# Altium schematic sheet Analog Transmission.SchDoc
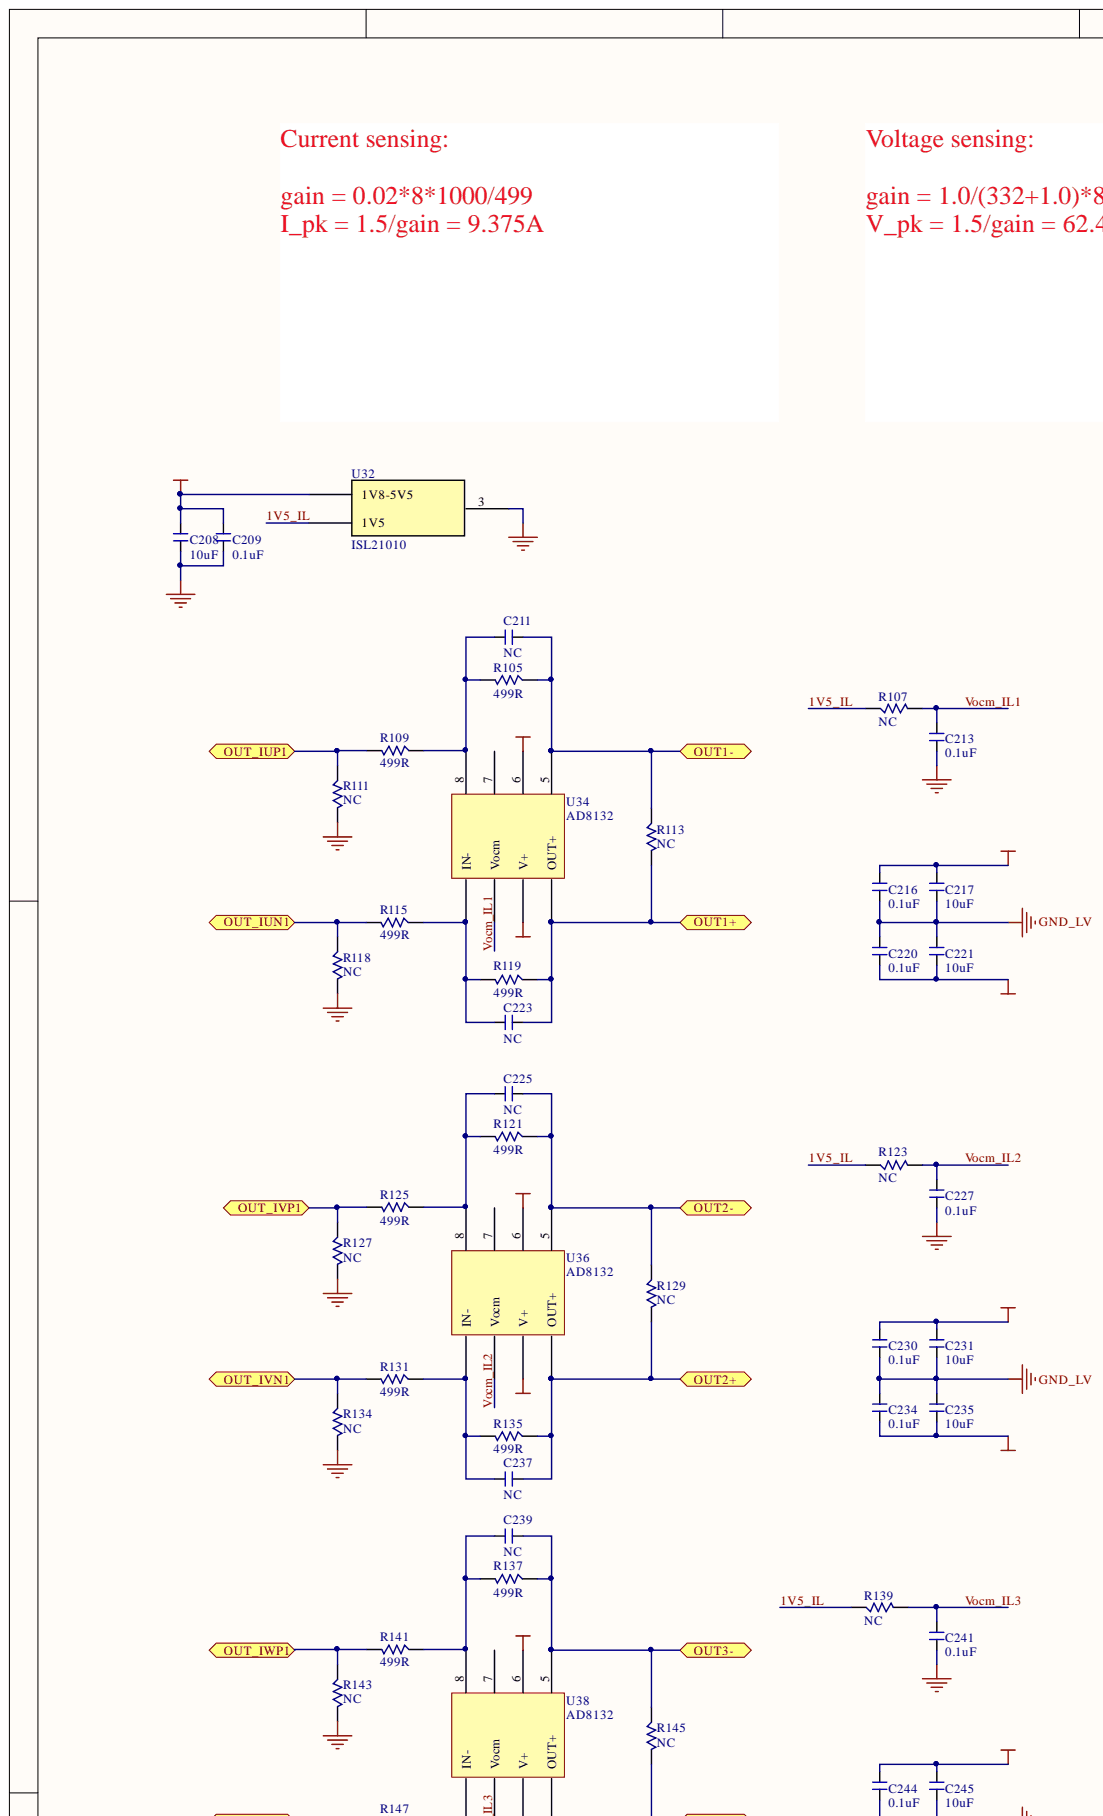
[diagram p0: Analog Transmission.SchDoc, top left]
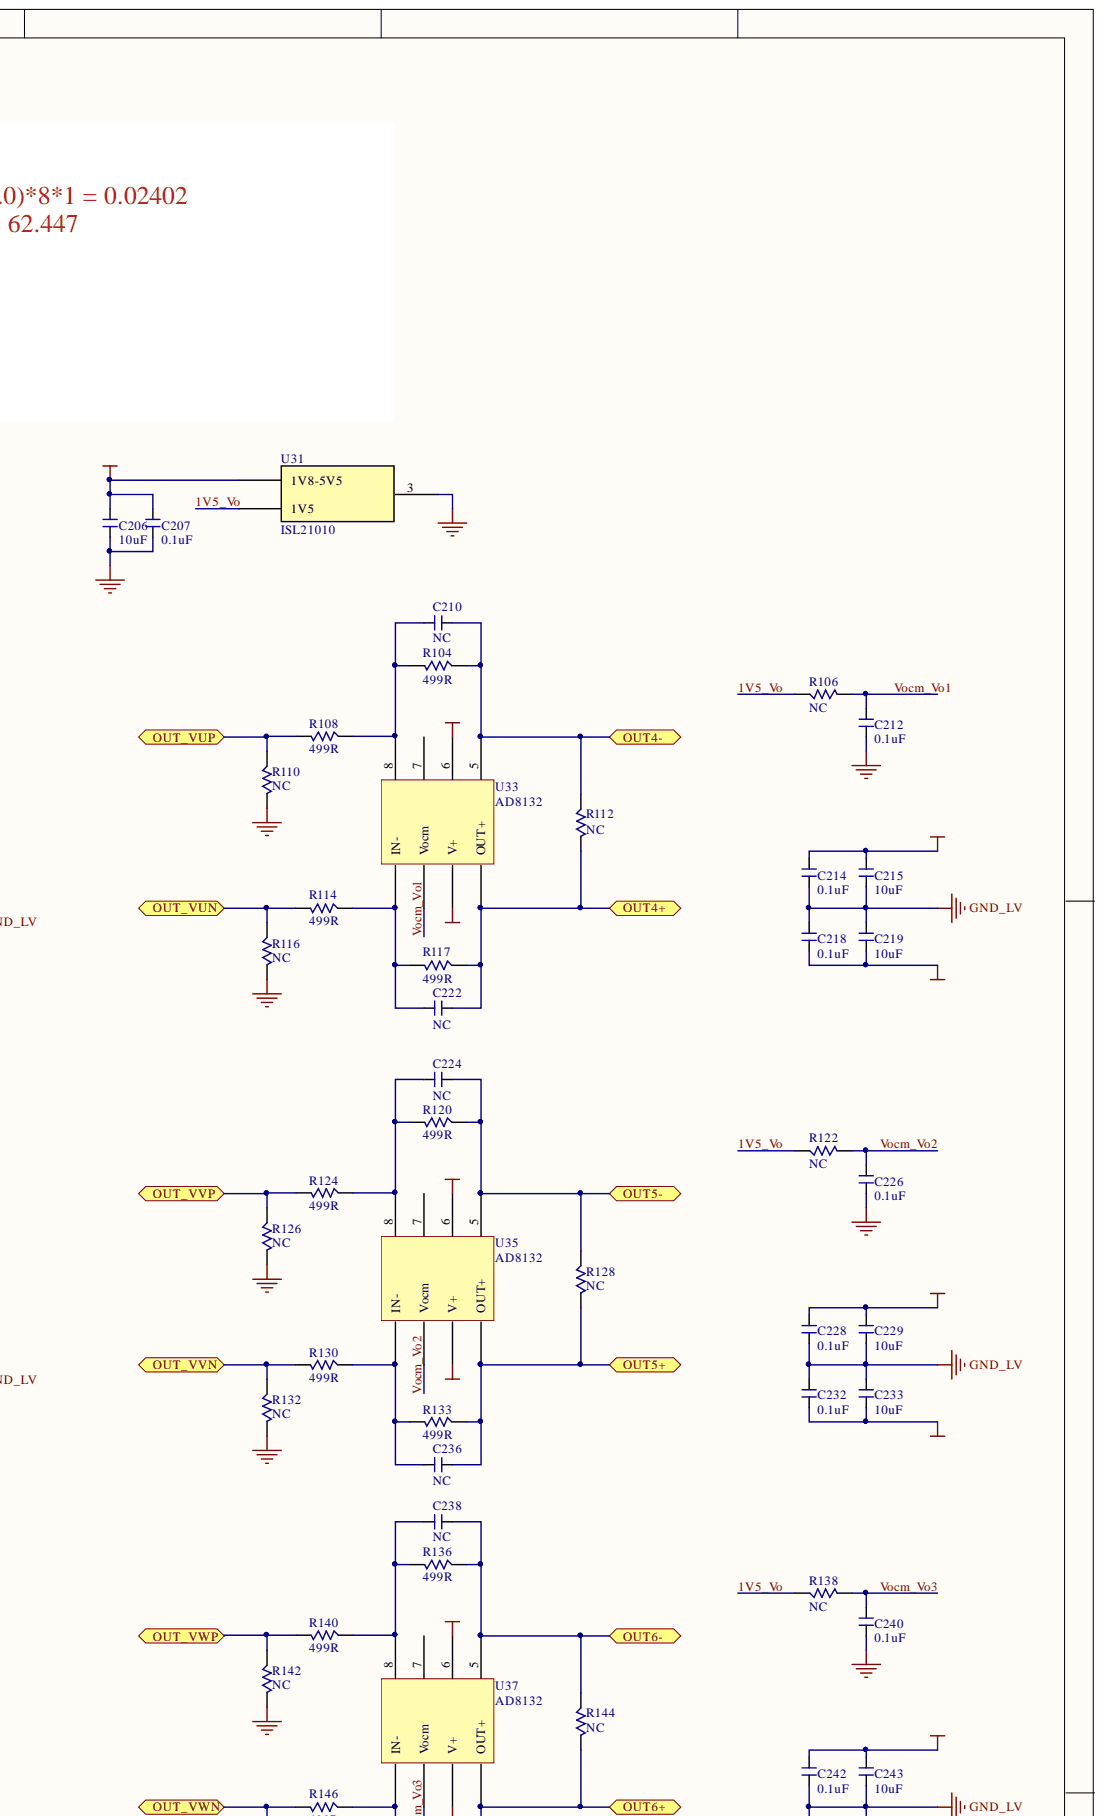
[diagram p1: Analog Transmission.SchDoc, top right]
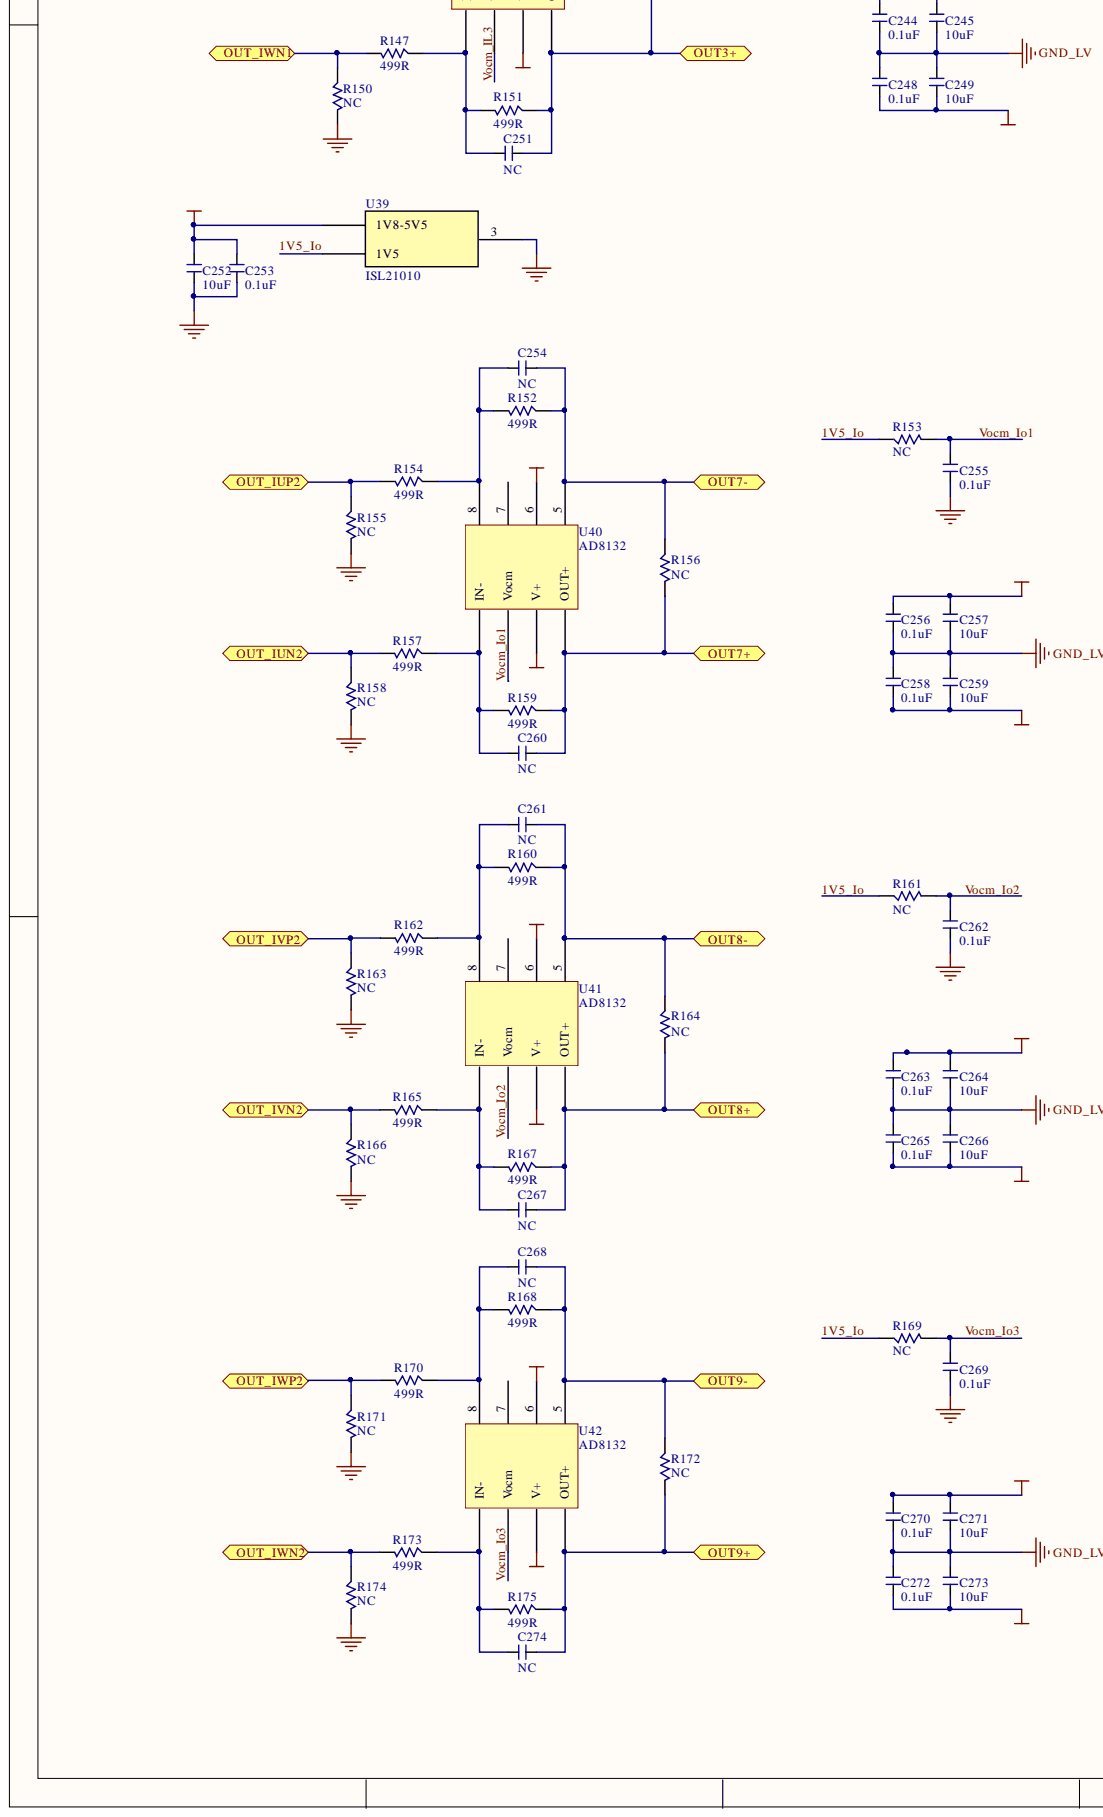
[diagram p2: Analog Transmission.SchDoc, bottom left]
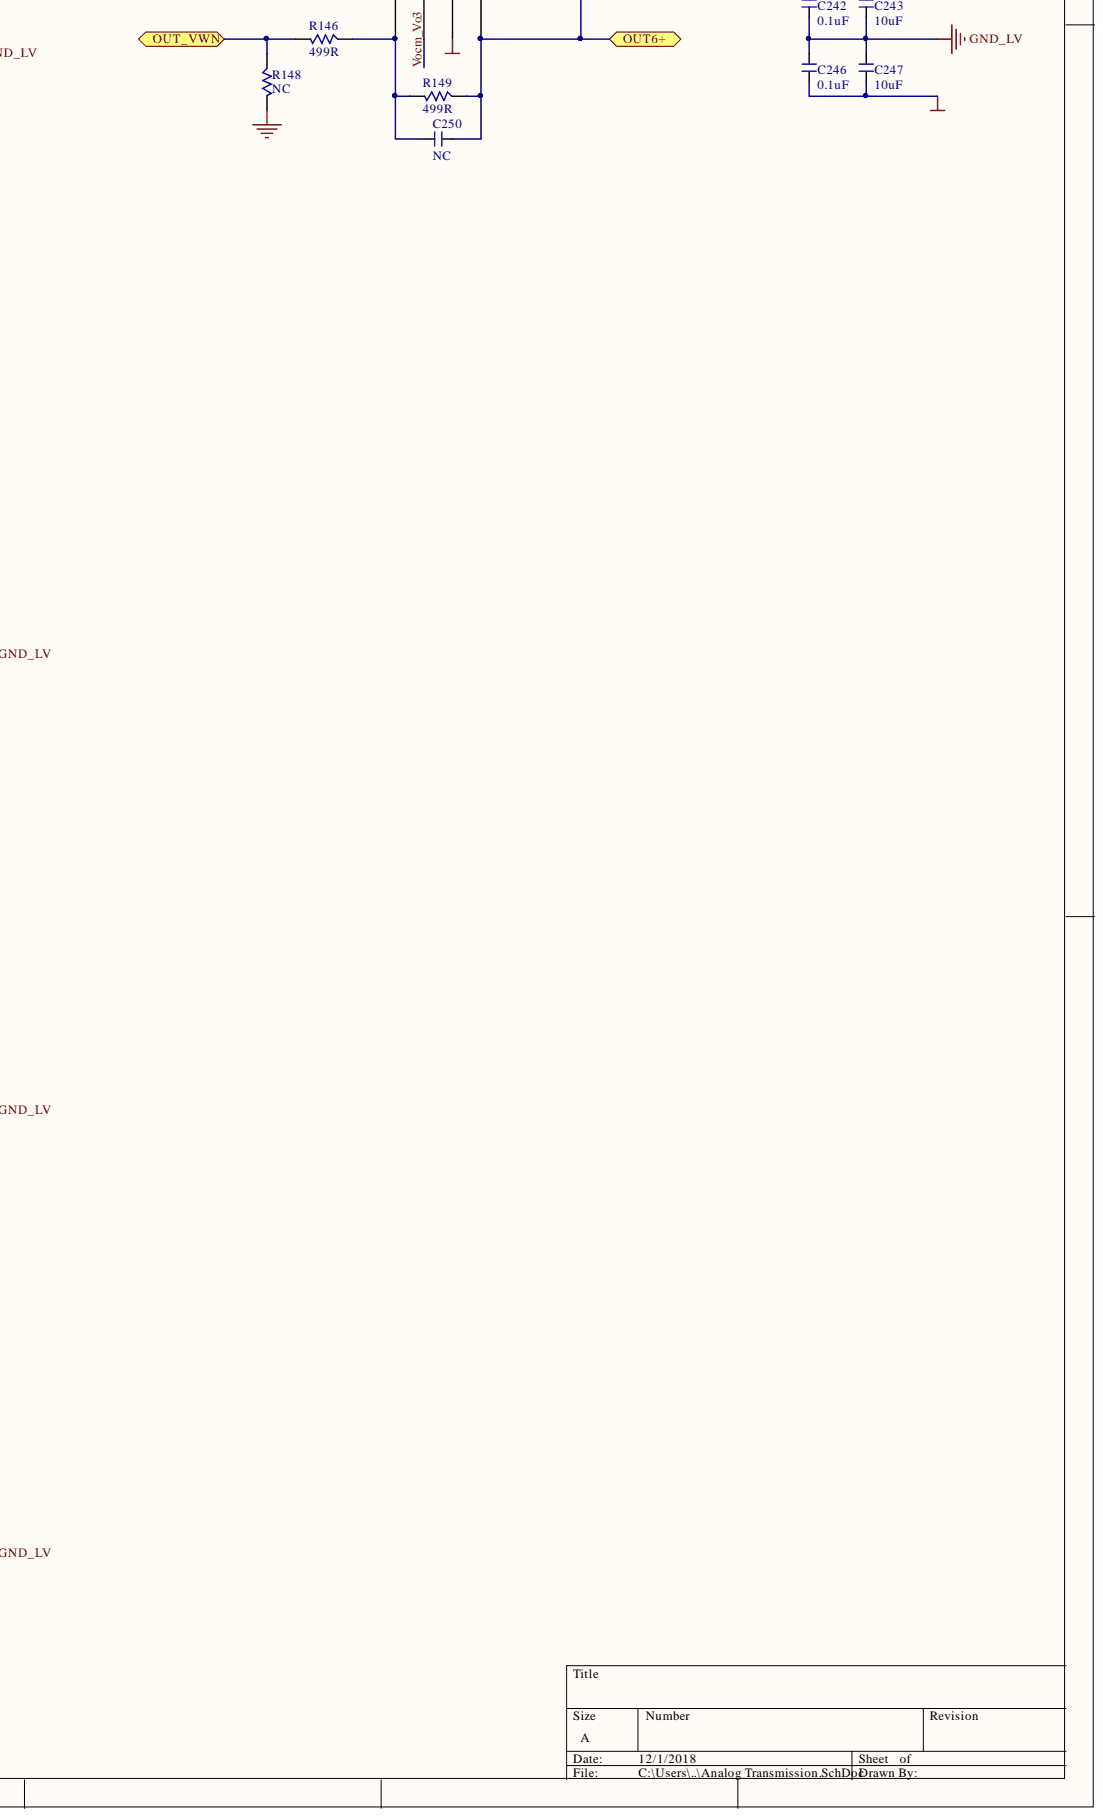
[diagram p3: Analog Transmission.SchDoc, bottom right]

## Parts
C206  value 10uF  [0603 (1608 Metric)]  (hidden comment: Cap 0603_new)
C207  value 0.1uF  [0603 (1608 Metric)]  (hidden comment: Cap 0603_new)
C208  value 10uF  [0603 (1608 Metric)]  (hidden comment: Cap 0603_new)
C209  value 0.1uF  [0603 (1608 Metric)]  (hidden comment: Cap 0603_new)
C210  value NC  [0603 (1608 Metric)]  (hidden comment: Cap 0603_new)
C211  value NC  [0603 (1608 Metric)]  (hidden comment: Cap 0603_new)
C212  value 0.1uF  [0603 (1608 Metric)]  (hidden comment: Cap 0603_new)
C213  value 0.1uF  [0603 (1608 Metric)]  (hidden comment: Cap 0603_new)
C214  value 0.1uF  [0603 (1608 Metric)]  (hidden comment: Cap 0603_new)
C215  value 10uF  [0603 (1608 Metric)]  (hidden comment: Cap 0603_new)
C216  value 0.1uF  [0603 (1608 Metric)]  (hidden comment: Cap 0603_new)
C217  value 10uF  [0603 (1608 Metric)]  (hidden comment: Cap 0603_new)
C218  value 0.1uF  [0603 (1608 Metric)]  (hidden comment: Cap 0603_new)
C219  value 10uF  [0603 (1608 Metric)]  (hidden comment: Cap 0603_new)
C220  value 0.1uF  [0603 (1608 Metric)]  (hidden comment: Cap 0603_new)
C221  value 10uF  [0603 (1608 Metric)]  (hidden comment: Cap 0603_new)
C222  value NC  [0603 (1608 Metric)]  (hidden comment: Cap 0603_new)
C223  value NC  [0603 (1608 Metric)]  (hidden comment: Cap 0603_new)
C224  value NC  [0603 (1608 Metric)]  (hidden comment: Cap 0603_new)
C225  value NC  [0603 (1608 Metric)]  (hidden comment: Cap 0603_new)
C226  value 0.1uF  [0603 (1608 Metric)]  (hidden comment: Cap 0603_new)
C227  value 0.1uF  [0603 (1608 Metric)]  (hidden comment: Cap 0603_new)
C228  value 0.1uF  [0603 (1608 Metric)]  (hidden comment: Cap 0603_new)
C229  value 10uF  [0603 (1608 Metric)]  (hidden comment: Cap 0603_new)
C230  value 0.1uF  [0603 (1608 Metric)]  (hidden comment: Cap 0603_new)
C231  value 10uF  [0603 (1608 Metric)]  (hidden comment: Cap 0603_new)
C232  value 0.1uF  [0603 (1608 Metric)]  (hidden comment: Cap 0603_new)
C233  value 10uF  [0603 (1608 Metric)]  (hidden comment: Cap 0603_new)
C234  value 0.1uF  [0603 (1608 Metric)]  (hidden comment: Cap 0603_new)
C235  value 10uF  [0603 (1608 Metric)]  (hidden comment: Cap 0603_new)
C236  value NC  [0603 (1608 Metric)]  (hidden comment: Cap 0603_new)
C237  value NC  [0603 (1608 Metric)]  (hidden comment: Cap 0603_new)
C238  value NC  [0603 (1608 Metric)]  (hidden comment: Cap 0603_new)
C239  value NC  [0603 (1608 Metric)]  (hidden comment: Cap 0603_new)
C240  value 0.1uF  [0603 (1608 Metric)]  (hidden comment: Cap 0603_new)
C241  value 0.1uF  [0603 (1608 Metric)]  (hidden comment: Cap 0603_new)
C242  value 0.1uF  [0603 (1608 Metric)]  (hidden comment: Cap 0603_new)
C243  value 10uF  [0603 (1608 Metric)]  (hidden comment: Cap 0603_new)
C244  value 0.1uF  [0603 (1608 Metric)]  (hidden comment: Cap 0603_new)
C245  value 10uF  [0603 (1608 Metric)]  (hidden comment: Cap 0603_new)
C246  value 0.1uF  [0603 (1608 Metric)]  (hidden comment: Cap 0603_new)
C247  value 10uF  [0603 (1608 Metric)]  (hidden comment: Cap 0603_new)
C248  value 0.1uF  [0603 (1608 Metric)]  (hidden comment: Cap 0603_new)
C249  value 10uF  [0603 (1608 Metric)]  (hidden comment: Cap 0603_new)
C250  value NC  [0603 (1608 Metric)]  (hidden comment: Cap 0603_new)
C251  value NC  [0603 (1608 Metric)]  (hidden comment: Cap 0603_new)
C252  value 10uF  [0603 (1608 Metric)]  (hidden comment: Cap 0603_new)
C253  value 0.1uF  [0603 (1608 Metric)]  (hidden comment: Cap 0603_new)
C254  value NC  [0603 (1608 Metric)]  (hidden comment: Cap 0603_new)
C255  value 0.1uF  [0603 (1608 Metric)]  (hidden comment: Cap 0603_new)
C256  value 0.1uF  [0603 (1608 Metric)]  (hidden comment: Cap 0603_new)
C257  value 10uF  [0603 (1608 Metric)]  (hidden comment: Cap 0603_new)
C258  value 0.1uF  [0603 (1608 Metric)]  (hidden comment: Cap 0603_new)
C259  value 10uF  [0603 (1608 Metric)]  (hidden comment: Cap 0603_new)
C260  value NC  [0603 (1608 Metric)]  (hidden comment: Cap 0603_new)
C261  value NC  [0603 (1608 Metric)]  (hidden comment: Cap 0603_new)
C262  value 0.1uF  [0603 (1608 Metric)]  (hidden comment: Cap 0603_new)
C263  value 0.1uF  [0603 (1608 Metric)]  (hidden comment: Cap 0603_new)
C264  value 10uF  [0603 (1608 Metric)]  (hidden comment: Cap 0603_new)
C265  value 0.1uF  [0603 (1608 Metric)]  (hidden comment: Cap 0603_new)
C266  value 10uF  [0603 (1608 Metric)]  (hidden comment: Cap 0603_new)
C267  value NC  [0603 (1608 Metric)]  (hidden comment: Cap 0603_new)
C268  value NC  [0603 (1608 Metric)]  (hidden comment: Cap 0603_new)
C269  value 0.1uF  [0603 (1608 Metric)]  (hidden comment: Cap 0603_new)
C270  value 0.1uF  [0603 (1608 Metric)]  (hidden comment: Cap 0603_new)
C271  value 10uF  [0603 (1608 Metric)]  (hidden comment: Cap 0603_new)
C272  value 0.1uF  [0603 (1608 Metric)]  (hidden comment: Cap 0603_new)
C273  value 10uF  [0603 (1608 Metric)]  (hidden comment: Cap 0603_new)
C274  value NC  [0603 (1608 Metric)]  (hidden comment: Cap 0603_new)
R104  value 499R  [0603 (1608 Metric)]  (hidden comment: Resistor 0603)
R105  value 499R  [0603 (1608 Metric)]  (hidden comment: Resistor 0603)
R106  value NC  [0603 (1608 Metric)]  (hidden comment: Resistor 0603)
R107  value NC  [0603 (1608 Metric)]  (hidden comment: Resistor 0603)
R108  value 499R  [0603 (1608 Metric)]  (hidden comment: Resistor 0603)
R109  value 499R  [0603 (1608 Metric)]  (hidden comment: Resistor 0603)
R110  value NC  [0603 (1608 Metric)]  (hidden comment: Resistor 0603)
R111  value NC  [0603 (1608 Metric)]  (hidden comment: Resistor 0603)
R112  value NC  [0603 (1608 Metric)]  (hidden comment: Resistor 0603)
R113  value NC  [0603 (1608 Metric)]  (hidden comment: Resistor 0603)
R114  value 499R  [0603 (1608 Metric)]  (hidden comment: Resistor 0603)
R115  value 499R  [0603 (1608 Metric)]  (hidden comment: Resistor 0603)
R116  value NC  [0603 (1608 Metric)]  (hidden comment: Resistor 0603)
R117  value 499R  [0603 (1608 Metric)]  (hidden comment: Resistor 0603)
R118  value NC  [0603 (1608 Metric)]  (hidden comment: Resistor 0603)
R119  value 499R  [0603 (1608 Metric)]  (hidden comment: Resistor 0603)
R120  value 499R  [0603 (1608 Metric)]  (hidden comment: Resistor 0603)
R121  value 499R  [0603 (1608 Metric)]  (hidden comment: Resistor 0603)
R122  value NC  [0603 (1608 Metric)]  (hidden comment: Resistor 0603)
R123  value NC  [0603 (1608 Metric)]  (hidden comment: Resistor 0603)
R124  value 499R  [0603 (1608 Metric)]  (hidden comment: Resistor 0603)
R125  value 499R  [0603 (1608 Metric)]  (hidden comment: Resistor 0603)
R126  value NC  [0603 (1608 Metric)]  (hidden comment: Resistor 0603)
R127  value NC  [0603 (1608 Metric)]  (hidden comment: Resistor 0603)
R128  value NC  [0603 (1608 Metric)]  (hidden comment: Resistor 0603)
R129  value NC  [0603 (1608 Metric)]  (hidden comment: Resistor 0603)
R130  value 499R  [0603 (1608 Metric)]  (hidden comment: Resistor 0603)
R131  value 499R  [0603 (1608 Metric)]  (hidden comment: Resistor 0603)
R132  value NC  [0603 (1608 Metric)]  (hidden comment: Resistor 0603)
R133  value 499R  [0603 (1608 Metric)]  (hidden comment: Resistor 0603)
R134  value NC  [0603 (1608 Metric)]  (hidden comment: Resistor 0603)
R135  value 499R  [0603 (1608 Metric)]  (hidden comment: Resistor 0603)
R136  value 499R  [0603 (1608 Metric)]  (hidden comment: Resistor 0603)
R137  value 499R  [0603 (1608 Metric)]  (hidden comment: Resistor 0603)
R138  value NC  [0603 (1608 Metric)]  (hidden comment: Resistor 0603)
R139  value NC  [0603 (1608 Metric)]  (hidden comment: Resistor 0603)
R140  value 499R  [0603 (1608 Metric)]  (hidden comment: Resistor 0603)
R141  value 499R  [0603 (1608 Metric)]  (hidden comment: Resistor 0603)
R142  value NC  [0603 (1608 Metric)]  (hidden comment: Resistor 0603)
R143  value NC  [0603 (1608 Metric)]  (hidden comment: Resistor 0603)
R144  value NC  [0603 (1608 Metric)]  (hidden comment: Resistor 0603)
R145  value NC  [0603 (1608 Metric)]  (hidden comment: Resistor 0603)
R146  value 499R  [0603 (1608 Metric)]  (hidden comment: Resistor 0603)
R147  value 499R  [0603 (1608 Metric)]  (hidden comment: Resistor 0603)
R148  value NC  [0603 (1608 Metric)]  (hidden comment: Resistor 0603)
R149  value 499R  [0603 (1608 Metric)]  (hidden comment: Resistor 0603)
R150  value NC  [0603 (1608 Metric)]  (hidden comment: Resistor 0603)
R151  value 499R  [0603 (1608 Metric)]  (hidden comment: Resistor 0603)
R152  value 499R  [0603 (1608 Metric)]  (hidden comment: Resistor 0603)
R153  value NC  [0603 (1608 Metric)]  (hidden comment: Resistor 0603)
R154  value 499R  [0603 (1608 Metric)]  (hidden comment: Resistor 0603)
R155  value NC  [0603 (1608 Metric)]  (hidden comment: Resistor 0603)
R156  value NC  [0603 (1608 Metric)]  (hidden comment: Resistor 0603)
R157  value 499R  [0603 (1608 Metric)]  (hidden comment: Resistor 0603)
R158  value NC  [0603 (1608 Metric)]  (hidden comment: Resistor 0603)
R159  value 499R  [0603 (1608 Metric)]  (hidden comment: Resistor 0603)
R160  value 499R  [0603 (1608 Metric)]  (hidden comment: Resistor 0603)
R161  value NC  [0603 (1608 Metric)]  (hidden comment: Resistor 0603)
R162  value 499R  [0603 (1608 Metric)]  (hidden comment: Resistor 0603)
R163  value NC  [0603 (1608 Metric)]  (hidden comment: Resistor 0603)
R164  value NC  [0603 (1608 Metric)]  (hidden comment: Resistor 0603)
R165  value 499R  [0603 (1608 Metric)]  (hidden comment: Resistor 0603)
R166  value NC  [0603 (1608 Metric)]  (hidden comment: Resistor 0603)
R167  value 499R  [0603 (1608 Metric)]  (hidden comment: Resistor 0603)
R168  value 499R  [0603 (1608 Metric)]  (hidden comment: Resistor 0603)
R169  value NC  [0603 (1608 Metric)]  (hidden comment: Resistor 0603)
R170  value 499R  [0603 (1608 Metric)]  (hidden comment: Resistor 0603)
R171  value NC  [0603 (1608 Metric)]  (hidden comment: Resistor 0603)
R172  value NC  [0603 (1608 Metric)]  (hidden comment: Resistor 0603)
R173  value 499R  [0603 (1608 Metric)]  (hidden comment: Resistor 0603)
R174  value NC  [0603 (1608 Metric)]  (hidden comment: Resistor 0603)
R175  value 499R  [0603 (1608 Metric)]  (hidden comment: Resistor 0603)
U31  ISL21010  [SOT_23]
U32  ISL21010  [SOT_23]
U33  AD8132  [SO8_L]
U34  AD8132  [SO8_L]
U35  AD8132  [SO8_L]
U36  AD8132  [SO8_L]
U37  AD8132  [SO8_L]
U38  AD8132  [SO8_L]
U39  ISL21010  [SOT_23]
U40  AD8132  [SO8_L]
U41  AD8132  [SO8_L]
U42  AD8132  [SO8_L]

## Ports
OUT1+, OUT1-, OUT2+, OUT2-, OUT3+, OUT3-, OUT4+, OUT4-, OUT5+, OUT5-, OUT6+, OUT6-, OUT7+, OUT7-, OUT8+, OUT8-, OUT9+, OUT9-, OUT_IUN1, OUT_IUN2, OUT_IUP1, OUT_IUP2, OUT_IVN1, OUT_IVN2, OUT_IVP1, OUT_IVP2, OUT_IWN1, OUT_IWN2, OUT_IWP1, OUT_IWP2, OUT_VUN, OUT_VUP, OUT_VVN, OUT_VVP, OUT_VWN, OUT_VWP

## Nets
+5V_LV: C206.2 C207.2 C208.2 C209.2 C214.2 C215.2 C216.2 C217.2 C228.2 C229.2 C230.2 C231.2 C242.2 C243.2 C244.2 C245.2 C252.2 C253.2 C256.2 C257.2 C263.2 C264.2 C270.2 C271.2 U31.1 U32.1 U33.3(V+) U34.3(V+) U35.3(V+) U36.3(V+) U37.3(V+) U38.3(V+) U39.1 U40.3(V+) U41.3(V+) U42.3(V+)
-5V_LV: C218.1 C219.1 C220.1 C221.1 C232.1 C233.1 C234.1 C235.1 C246.1 C247.1 C248.1 C249.1 C258.1 C259.1 C265.1 C266.1 C272.1 C273.1 U33.6 U34.6 U35.6 U36.6 U37.6 U38.6 U40.6 U41.6 U42.6
GND_LV: C206.1 C207.1 C208.1 C209.1 C212.1 C213.1 C214.1 C215.1 C216.1 C217.1 C218.2 C219.2 C220.2 C221.2 C226.1 C227.1 C228.1 C229.1 C230.1 C231.1 C232.2 C233.2 C234.2 C235.2 C240.1 C241.1 C242.1 C243.1 C244.1 C245.1 C246.2 C247.2 C248.2 C249.2 C252.1 C253.1 C255.1 C256.1 C257.1 C258.2 C259.2 C262.1 C263.1 C264.1 C265.2 C266.2 C269.1 C270.1 C271.1 C272.2 C273.2 R110.2 R111.2 R116.2 R118.2 R126.2 R127.2 R132.2 R134.2 R142.2 R143.2 R148.2 R150.2 R155.2 R158.2 R163.2 R166.2 R171.2 R174.2 U31.3 U32.3 U39.3
1V5_IL: R107.1 R123.1 R139.1 U32.2
1V5_Io: R153.1 R161.1 R169.1 U39.2
1V5_Vo: R106.1 R122.1 R138.1 U31.2
OUT_IUN1: R115.2 R118.1 ; port OUT_IUN1
OUT_IUN2: R157.2 R158.1 ; port OUT_IUN2
OUT_IUP1: R109.2 R111.1 ; port OUT_IUP1
OUT_IUP2: R154.2 R155.1 ; port OUT_IUP2
OUT_IVN1: R131.2 R134.1 ; port OUT_IVN1
OUT_IVN2: R165.2 R166.1 ; port OUT_IVN2
OUT_IVP1: R125.2 R127.1 ; port OUT_IVP1
OUT_IVP2: R162.2 R163.1 ; port OUT_IVP2
OUT_IWN1: R147.2 R150.1 ; port OUT_IWN1
OUT_IWN2: R173.2 R174.1 ; port OUT_IWN2
OUT_IWP1: R141.2 R143.1 ; port OUT_IWP1
OUT_IWP2: R170.2 R171.1 ; port OUT_IWP2
OUT_VUN: R114.2 R116.1 ; port OUT_VUN
OUT_VUP: R108.2 R110.1 ; port OUT_VUP
OUT_VVN: R130.2 R132.1 ; port OUT_VVN
OUT_VVP: R124.2 R126.1 ; port OUT_VVP
OUT_VWN: R146.2 R148.1 ; port OUT_VWN
OUT_VWP: R140.2 R142.1 ; port OUT_VWP
Vocm_IL1: C213.2 R107.2 U34.2(Vocm)
Vocm_IL2: C227.2 R123.2 U36.2(Vocm)
Vocm_IL3: C241.2 R139.2 U38.2(Vocm)
Vocm_Io1: C255.2 R153.2 U40.2(Vocm)
Vocm_Io2: C262.2 R161.2 U41.2(Vocm)
Vocm_Io3: C269.2 R169.2 U42.2(Vocm)
Vocm_Vo1: C212.2 R106.2 U33.2(Vocm)
Vocm_Vo2: C226.2 R122.2 U35.2(Vocm)
Vocm_Vo3: C240.2 R138.2 U37.2(Vocm)
NetC210_1: C210.1 R104.1 R112.1 U33.5
NetC210_2: C210.2 R104.2 R108.1 U33.8
NetC211_1: C211.1 R105.1 R113.1 U34.5
NetC211_2: C211.2 R105.2 R109.1 U34.8
NetC222_1: C222.1 R112.2 R117.1 U33.4(OUT+)
NetC222_2: C222.2 R114.1 R117.2 U33.1(IN-)
NetC223_1: C223.1 R113.2 R119.1 U34.4(OUT+)
NetC223_2: C223.2 R115.1 R119.2 U34.1(IN-)
NetC224_1: C224.1 R120.1 R128.1 U35.5
NetC224_2: C224.2 R120.2 R124.1 U35.8
NetC225_1: C225.1 R121.1 R129.1 U36.5
NetC225_2: C225.2 R121.2 R125.1 U36.8
NetC236_1: C236.1 R128.2 R133.1 U35.4(OUT+)
NetC236_2: C236.2 R130.1 R133.2 U35.1(IN-)
NetC237_1: C237.1 R129.2 R135.1 U36.4(OUT+)
NetC237_2: C237.2 R131.1 R135.2 U36.1(IN-)
NetC238_1: C238.1 R136.1 R144.1 U37.5
NetC238_2: C238.2 R136.2 R140.1 U37.8
NetC239_1: C239.1 R137.1 R145.1 U38.5
NetC239_2: C239.2 R137.2 R141.1 U38.8
NetC250_1: C250.1 R144.2 R149.1 U37.4(OUT+)
NetC250_2: C250.2 R146.1 R149.2 U37.1(IN-)
NetC251_1: C251.1 R145.2 R151.1 U38.4(OUT+)
NetC251_2: C251.2 R147.1 R151.2 U38.1(IN-)
NetC254_1: C254.1 R152.1 R156.1 U40.5
NetC254_2: C254.2 R152.2 R154.1 U40.8
NetC260_1: C260.1 R156.2 R159.1 U40.4(OUT+)
NetC260_2: C260.2 R157.1 R159.2 U40.1(IN-)
NetC261_1: C261.1 R160.1 R164.1 U41.5
NetC261_2: C261.2 R160.2 R162.1 U41.8
NetC267_1: C267.1 R164.2 R167.1 U41.4(OUT+)
NetC267_2: C267.2 R165.1 R167.2 U41.1(IN-)
NetC268_1: C268.1 R168.1 R172.1 U42.5
NetC268_2: C268.2 R168.2 R170.1 U42.8
NetC274_1: C274.1 R172.2 R175.1 U42.4(OUT+)
NetC274_2: C274.2 R173.1 R175.2 U42.1(IN-)

Not connected: U33 7; U34 7; U35 7; U36 7; U37 7; U38 7; U40 7; U41 7; U42 7

## Library data of the parts
C206 C208 C215 C217 C219 C221 C229 C231 C233 C235 C243 C245 C247 C249 C252 C257 C259 C264 C266 C271 C273 = Cap 0603_new: CAP CER 10UF 35V X5R 0805; part: 490-10515-1-ND; Component Kind: Standard; Library Name: Library_Microgrid.SchLib
C207 C209 C212-C214 C216 C218 C220 C226-C228 C230 C232 C234 C240-C242 C244 C246 C248 C253 C255 C256 C258 C262 C263 C265 C269 C270 C272 = Cap 0603_new: CAP CER 0.1UF 50V Y5V 0603; part: 311-1343-6-ND; Component Kind: Standard; Library Name: Library_Microgrid.SchLib
C210 C211 C222-C225 C236-C239 C250 C251 C254 C260 C261 C267 C268 C274 = Cap 0603_new: NC; Component Kind: Standard; Library Name: Library_Microgrid.SchLib
R104 R105 R108 R109 R114 R115 R117 R119-R121 R124 R125 R130 R131 R133 R135-R137 R140 R141 R146 R147 R149 R151 R152 R154 R157 R159 R160 R162 R165 R167 R168 R170 R173 R175 = Resistor 0603: RES SMD 499 OHM 0.1% 1/10W 0603; Part: RG16P499BCT-ND; Component Kind: Standard; Library Name: Library_Microgrid.SchLib
R106 R107 R110-R113 R116 R118 R122 R123 R126-R129 R132 R134 R138 R139 R142-R145 R148 R150 R153 R155 R156 R158 R161 R163 R164 R166 R169 R171 R172 R174 = Resistor 0603: NC; Component Kind: Standard; Library Name: Library_Microgrid.SchLib
U31 U32 U39 = ISL21010: Series Voltage Reference IC ±0.2% 25mA SOT-23-3; Part: ISL21010CFH315Z-TKCT-ND; Component Kind: Standard; Library Name: Library_Microgrid.SchLib
U33-U38 U40-U42 = AD8132: IC OPAMP DIFF 360MHZ 8MSOP; Part: AD8132WARMZ-R7CT-ND; Component Kind: Standard; Library Name: Library_Microgrid.SchLib
